# Altium schematic sheet Algduino.SchDoc
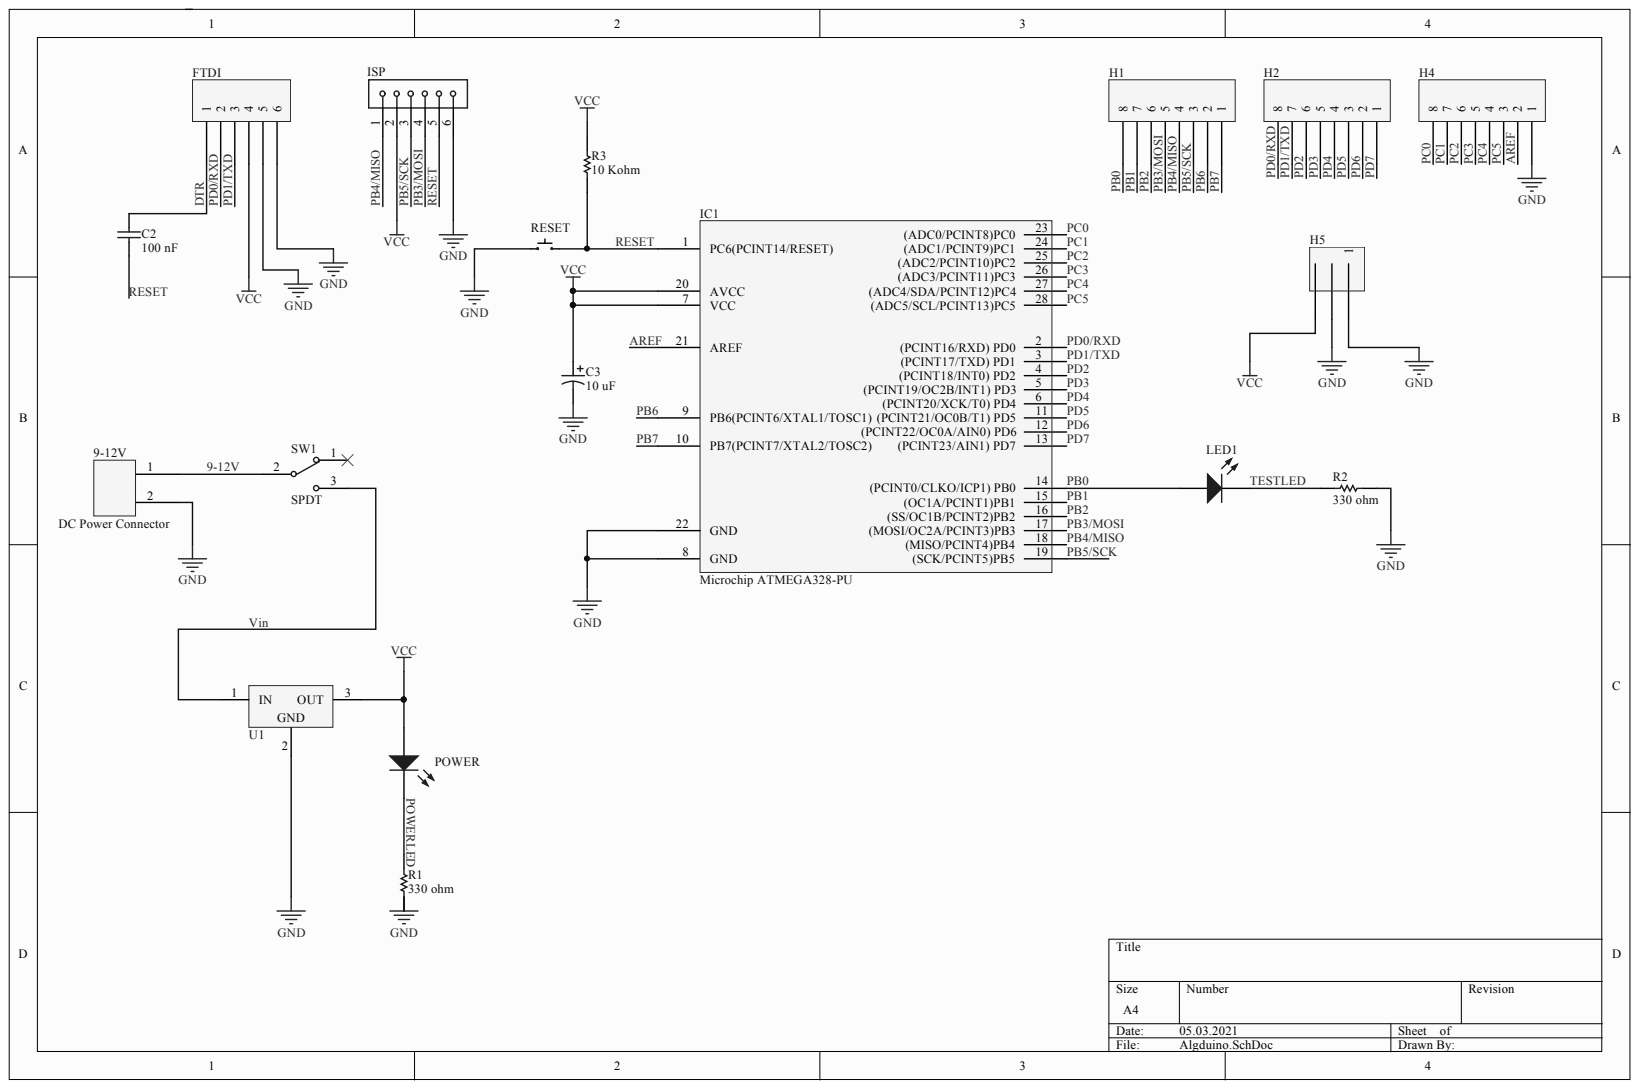
[diagram p0: Algduino.SchDoc]

## Parts
9-12V  DC Power Connector  [PCB-d0c7wxilwqij9vxrrifw-1]
C2  100 nF  [PCB-l4zci1ynknckpo24ombh-1]
C3  10 uF  [PCB-muhsxzq72haegj0aiilw-1]
FTDI  (no value)  [PCB-ibw2rt28i6i7ht9223r0-1]
H1  (no value)  [PCBComponent_1 - duplicate]
H2  (no value)  [PCBComponent_1 - duplicate]
H4  (no value)  [PCBComponent_1 - duplicate]
H5  Würth Elektronik 61300311821  [PCB-s4wei59tamiram436nro-1]  (value not drawn)
IC1  Microchip ATMEGA328-PU  Manufacturer Part Number: ATMEGA328-PU  [DIP28RT]
ISP  (no value)  [PCB-kenf6hpwjn12nkgse9k3-1]
LED1  (no value)  [Type 5mm]
POWER  (no value)  [Type 5mm]
R1  330 ohm  [PCB-e6dvdw46ah2pjbdyzwgn-1]
R2  330 ohm  [PCB-e6dvdw46ah2pjbdyzwgn-1]
R3  10 Kohm  [PCB-e6dvdw46ah2pjbdyzwgn-1]
RESET  (no value)  [PCB-tvsgc6o234lkyfsfr4w0-1]
SW1  SPDT  [ESWI-EG1218_V]
U1  ST Microelectronics L7812CV  [TO-220]  (value not drawn)

## Nets
GND: 9-12V.2 C3.2 FTDI.5 FTDI.6 H4.1 H5.1 H5.2 IC1.8(GND) IC1.22(GND) ISP.6 R1.1 R2.1 RESET.A U1.2
VCC: C3.1 FTDI.4 H5.3 IC1.7(VCC) IC1.20(AVCC) ISP.2 POWER.2 R3.2 U1.3
9-12V: 9-12V.1 SW1.2
AREF: H4.2 IC1.21(AREF)
DTR: C2.2 FTDI.1
PB0: H1.8 IC1.14((PCINT0/CLKO/ICP1)_PB0) LED1.2
PB1: H1.7 IC1.15((OC1A/PCINT1)PB1)
PB2: H1.6 IC1.16((SS/OC1B/PCINT2)PB2)
PB3/MOSI: H1.5 IC1.17((MOSI/OC2A/PCINT3)PB3) ISP.4
PB4/MISO: H1.4 IC1.18((MISO/PCINT4)PB4) ISP.1
PB5/SCK: H1.3 IC1.19((SCK/PCINT5)PB5) ISP.3
PB6: H1.2 IC1.9(PB6(PCINT6/XTAL1/TOSC1))
PB7: H1.1 IC1.10(PB7(PCINT7/XTAL2/TOSC2))
PC0: H4.8 IC1.23((ADC0/PCINT8)PC0)
PC1: H4.7 IC1.24((ADC1/PCINT9)PC1)
PC2: H4.6 IC1.25((ADC2/PCINT10)PC2)
PC3: H4.5 IC1.26((ADC3/PCINT11)PC3)
PC4: H4.4 IC1.27((ADC4/SDA/PCINT12)PC4)
PC5: H4.3 IC1.28((ADC5/SCL/PCINT13)PC5)
PD0/RXD: FTDI.2 H2.8 IC1.2((PCINT16/RXD)_PD0)
PD1/TXD: FTDI.3 H2.7 IC1.3((PCINT17/TXD)_PD1)
PD2: H2.6 IC1.4((PCINT18/INT0)_PD2)
PD3: H2.5 IC1.5((PCINT19/OC2B/INT1)_PD3)
PD4: H2.4 IC1.6((PCINT20/XCK/T0)_PD4)
PD5: H2.3 IC1.11((PCINT21/OC0B/T1)_PD5)
PD6: H2.2 IC1.12((PCINT22/OC0A/AIN0)_PD6)
PD7: H2.1 IC1.13((PCINT23/AIN1)_PD7)
POWERLED: POWER.1 R1.2
RESET: C2.1 IC1.1(PC6(PCINT14/RESET)) ISP.5 R3.1 RESET.B
TESTLED: LED1.1 R2.2
VIN: SW1.3 U1.1
N00005:

Not connected: SW1 1

## Library data of the parts
9-12V = CMP-752867-4: TERM BLOCK 5.08MM VERT 2POS PCB; Manufacturer Part Number: OSTTC022162; Number of Positions: 2; Pin Pitch: 0.00508 m; Component Kind: Standard; Contact Material: Brass; Flammability Rating: UL 94 V-0; Housing Color: Blue; Housing Material: Nylon; Library Name: CommunityVault; Mounting Style: Through Hole; Number of Rows: 1; OctopartID: 98f651f807384731; Octopart Url: https://octopart.com/osttc022162-on+shore+technology-309928; Packaging: Bulk; Size-Height: 0.01 m; Size-Length: 0.01016 m; Size-Width: 0.0076 m
C2 = CMP-7d20b42952c2a2e7-3: Capacitor; Ceramic; Cap 0.1 uF; Tol -20, +80%; Vol-Rtg 50 VDC; Radial; Y5V; Tape & Reel; Manufacturer Part Number: K104Z15Y5VF5TL2; Capacitance Tolerance: +80/-20%; Lead-Free Status: Contains Lead; Lead Length: 30.0 mm; Voltage Rating (DC): 50.0 V; Capacitance: 100 nF; Component Kind: Standard; Dielectric Characteristic: Y5V; Dielectric Material: Ceramic; Library Name: CommunityVault; Mounting Style: Through Hole; Number of Pins: 2; OctopartID: 7d20b42952c2a2e7; Octopart URL: http://octopart.com/k104z15y5vf5tl2-vishay+bccomponents-199300; Packaging: Cut Tape (CT), Tape & Reel (TR); RoHS: Compliant; Size-Diameter: 500 µm; Size-Height: 4.00 mm; Size-Length: 4.00 mm; Size-Thickness: 2.60 mm; Size-Width: 4.00 mm
C3 = CMP-6a37a1f889ffb5f0-1: Cap Aluminum Lytic 10uF 50V 20% (5 X 11mm) Radial 2mm 13.27 Ohm 65mA 2000h 85Â°C Standard; Voltage Rating (DC): 50.0 V; Capacitance: 10 uF; Capacitance Tolerance: ±20%; Component Kind: Standard; Library Name: CommunityVault
FTDI = CMP-ab8563c5b2487442-4: THT Vertical Socket Header WR-PHD, Pitch 2.54 mm, Single Row, 6 pins; Component Kind: Standard; Library Name: CommunityVault
H1 H2 H4 = CMP-3ae9f053fafce52e-4: THT Vertical Socket Header WR-PHD, Pitch 2.54 mm, Single Row, 8 pins; Manufacturer Part Number: 61300811821; Contact Resistance: 20.0 mΩ; Dielectric Withstanding Voltage: 500 V; Gender: Female; Operating Voltage: 250 V; Pin Pitch: 2.54 mm; Component Kind: Standard; Contact Material: Copper Alloy; Library Name: CommunityVault; Mounting Style: Through Hole; OctopartID: 3ae9f053fafce52e; Octopart URL: https://octopart.com/61300811821-w%C3%BCrth+elektronik-61924723; Operating Temperature: -40.0 °C (min); Orientation: Vertical; Packaging: Tray
H5 = CMP-cde7075d0de71220-2: Single Row / Straight / Without Shroud Female header Female 3; Component Kind: Standard; Library Name: CommunityVault
IC1 = CMP-973184-20: ATmega Series 20 MHz 32 KB Flash 2 KB SRAM 8-Bit Microcontroller - DIP-28; RAM Memory Size: 2048 B; Case/Package: SPDIP; Component Kind: Standard; Library Name: CommunityVault; Mounting Style: Through Hole; Number of Pins: 28; OctopartID: 7b15b6bdd8a1a4d2; REACH SVHC Compliance: No SVHC; RoHS: Compliant; Simulation: ATMEGA 328 W
ISP = CMP-a5f4687120a57a33-1: Single Row 6 Position 2.54 mm Square Male Pins Unshrouded BERGSTIK II Header; Number of Contacts: 6; Component Kind: Standard; Contact Material: Phosphor Bronze; Gender: Male; Library Name: CommunityVault; Mounting Style: Through Hole; OctopartID: a5f4687120a57a33; Orientation: Straight
LED1 POWER = CMP-12518896-2: THT LED round mono-color color lens, WL-TMRW, Bright Green; Manufacturer Part Number: 151051VS04000; Forward Voltage: 2.1 V; Luminous Intensity: 0.14 cd; Viewing Angle: 40 °; Component Kind: Standard; Library Name: CommunityVault; OctopartID: 7dcdb5022d9da20a; Octopart Url: https://octopart.com/151051vs04000-w%C3%BCrth+elektronik-29717814; REACH SVHC Compliance: No SVHC; RoHS: Compliant
R1-R3 = CMP-2537800-8: Carbon Film Resistors - Through Hole 330Ohm 1/3W 1200PPM; Manufacturer Part Number: CFR25J330R; ELV Compliant: Compliant; Voltage Rating (DC): 250.0 V; Component Kind: Standard; Library Name: CommunityVault; Lifecycle Status: Active; OctopartID: 14dedd216034cb19; Octopart Url: https://octopart.com/cfr25j330r-te+connectivity-16077196; RoHS: Compliant
RESET = CMP-44068f6ead4c9bc1-3: Switch Tactile N.O. SPST Round Button PC Pins 0.02A 15VDC 2.6N Thru-Hole Bulk; Manufacturer Part Number: EVQ-PAG05R; Actuator Type: Standard; Contact Current Rating: 20.0 mA; Contacts Type: SPST-NO; Component Kind: Standard; Library Name: CommunityVault; Mounting Style: Through Hole, PC Board; Octopart URL: http://octopart.com/evq-pag05r-panasonic-40029228; Orientation: Vertical; Size-Height: 5.00 mm; Size-Length: 6.00 mm; Size-Width: 6.00 mm
SW1 = CMP-9a5a5340a2f5459d-9: Slide Switch, 500 V, -20 to 70 degC, 3-Pin THD, RoHS; Manufacturer Part Number: EG1218; Actuator Type: Standard; Current Rating: 200 mA; Lead-Free Status: Lead Free; Number of Positions: 2; Component Kind: Standard; Contact Material: Copper Alloy; Contact Plating: Silver; Contacts Type: SPDT; DatasheetVersion: Oct-15; Library Name: CommunityVault; Mounting Style: PCB, Through Hole; OctopartID: 9a5a5340a2f5459d; Octopart Url: http://octopart.com/eg1218-e-switch-1056201; Orientation: Vertical; PackageDescription: 3-Pin Through Hole Device, Body 11.6 x 4 mm, Pitch 2.5 mm; PackageReference: EG1218; Packaging: Bulk; RoHS: Compliant; Seller Landing  Url: https://octopart-clicks.com/click/track?country=US&ak=8157f166&sig=0e9adab&sid=459&ppid=1056201&vpid=1179261&ct=offers; Size-Height: 5.40 mm; Size-Length: 2.03 mm; Size-Width: 4.00 mm
U1 = CMP-16053872-24: 5V 1A Positive Linear Voltage Regulator TO-220; Dropout Voltage: 2.0 V; Input Voltage: 7-35V; Lead-Free Status: Lead Free; Number of Outputs: 1; Component Kind: Standard; Library Name: CommunityVault; Mounting Style: Through Hole; Number of Pins: 3; OctopartID: e4b72fe0923e3b70; Octopart Url: https://octopart.com/l7805cv-stmicroelectronics-39670767; Output Voltage: 5V; Polarity: Positive; REACH SVHC Compliance: No SVHC; RoHS: Compliant
